# Altium schematic sheet 08_ATK_DLRK3506J_4G.SchDoc
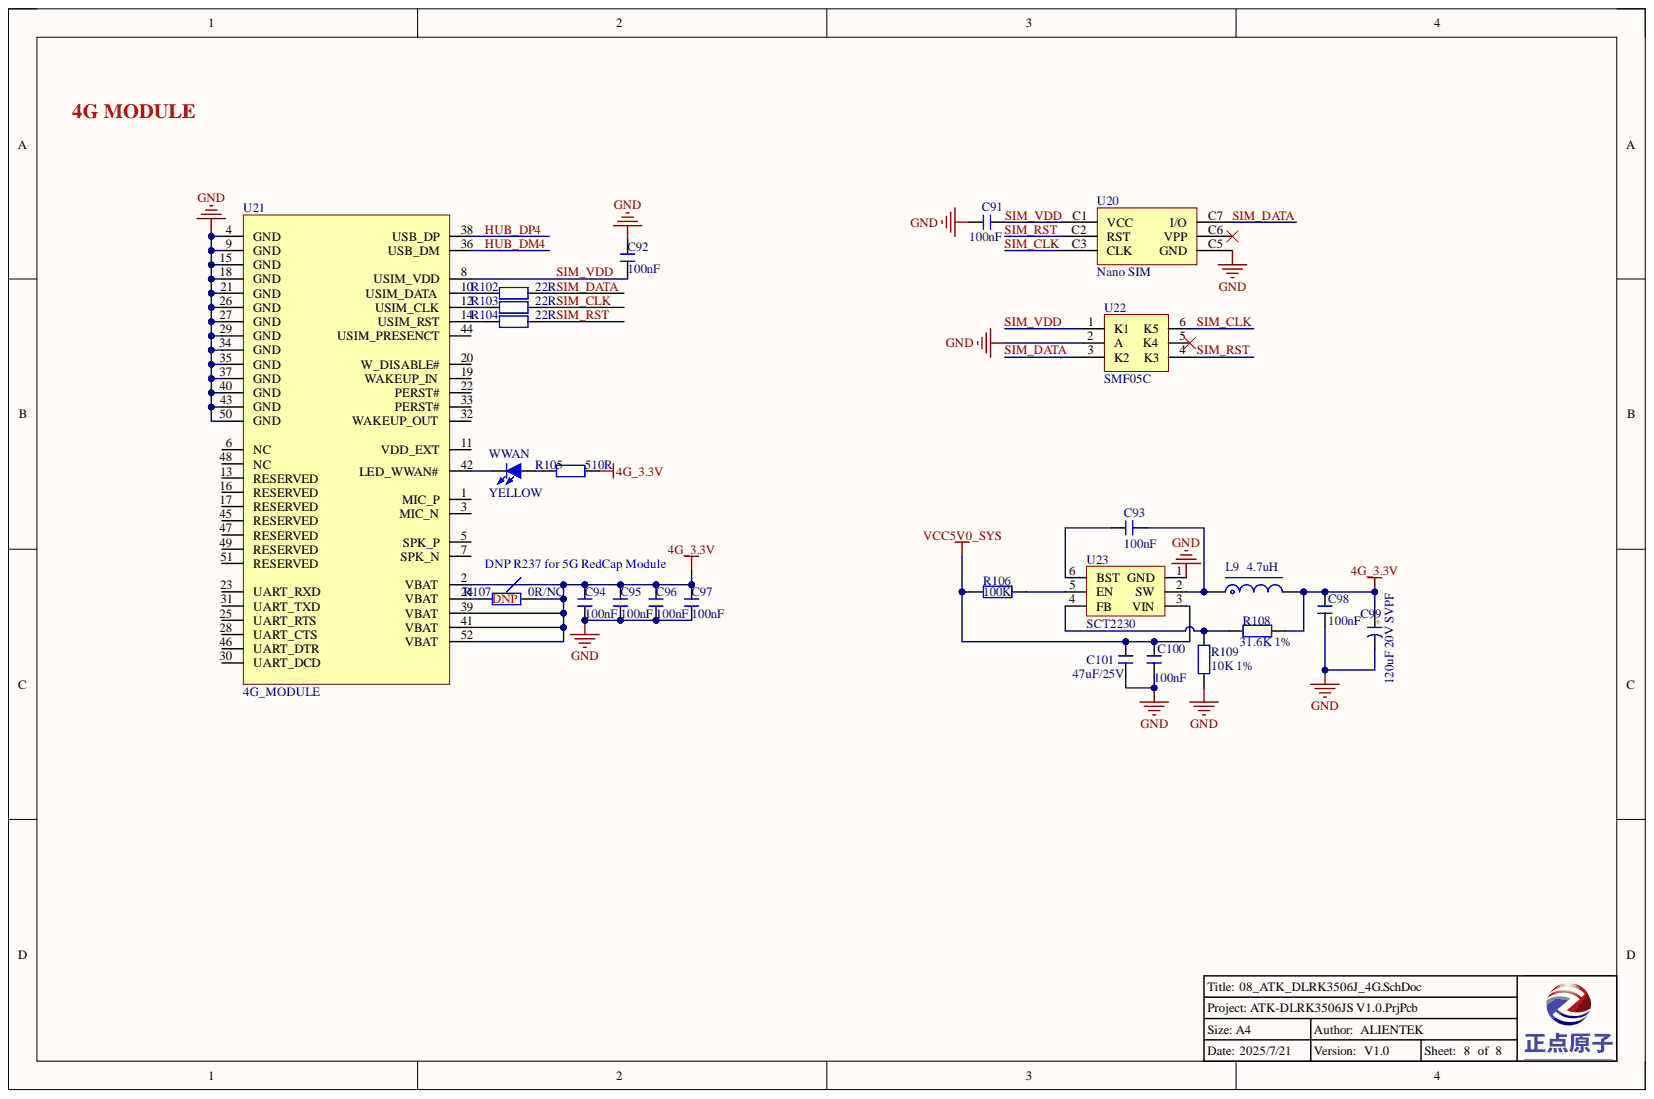
[diagram p0: 08_ATK_DLRK3506J_4G.SchDoc]

## Parts
C91  100nF  [C 0402]
C92  100nF  [C 0402]
C93  100nF  [C 0402]
C94  100nF  [C 0402]
C95  100nF  [C 0402]
C96  100nF  [C 0402]
C97  100nF  [C 0402]
C98  100nF  [C 0402]
C99  120uF 20V SVPF  [CM D(6.3*7.7)]
C100  100nF  [C 0402]
C101  47uF/25V  [C 1206]
L9  4.7uH  [L-SMD-0420]
R102  22R  [R 0402]
R103  22R  [R 0402]
R104  22R  [R 0402]
R105  510R  [R 0402]
R106  100K  [R 0402]
R107  0R/NC  [R 0402]
R108  31.6K 1%  [R 0402]
R109  10K 1%  [R 0402]
U20  Nano SIM  [Nano SIM 7P]
U21  4G_MODULE  [Mini PCIE 52P]
U22  SMF05C  [SOT363-6L]
U23  SCT2230  [SOT23-6N]
WWAN  YELLOW  [LED 0805G]

## Nets
4G_3.3V: C94.1 C95.1 C96.1 C97.1 C98.1 C99.1 L9.2 R105.1 R107.1 R108.2 U21.2(VBAT) U21.39(VBAT) U21.41(VBAT) U21.52(VBAT)
GND: C91.1 C92.1 C94.2 C95.2 C96.2 C97.2 C98.2 C99.2 C100.2 C101.1 R109.1 U20.C5(GND) U21.4(GND) U21.9(GND) U21.15(GND) U21.18(GND) U21.21(GND) U21.26(GND) U21.27(GND) U21.29(GND) U21.34(GND) U21.35(GND) U21.37(GND) U21.40(GND) U21.43(GND) U21.50(GND) U22.2(A) U23.1(GND)
VCC5V0_SYS: C100.1 C101.2 R106.1 U23.3(VIN)
HUB_DM4: U21.36(USB_DM)
HUB_DP4: U21.38(USB_DP)
SIM_CLK: R103.1 U20.C3(CLK) U22.6(K5)
SIM_DATA: R102.1 U20.C7(I/O) U22.3(K2)
SIM_RST: R104.1 U20.C2(RST) U22.4(K3)
SIM_VDD: C91.2 C92.2 U20.C1(VCC) U21.8(USIM_VDD) U22.1(K1)
NetC93_1: C93.1 U23.6(BST)
NetC93_2: C93.2 L9.1 U23.2(SW)
NetR102_2: R102.2 U21.10(USIM_DATA)
NetR103_2: R103.2 U21.12(USIM_CLK)
NetR104_2: R104.2 U21.14(USIM_RST)
NetR105_2: R105.2 WWAN.1
NetR106_2: R106.2 U23.5(EN)
NetR107_2: R107.2 U21.24(VBAT)
NetR108_1: R108.1 R109.2 U23.4(FB)
NetU21_42: U21.42(LED_WWAN#) WWAN.2

Not connected: U20 C6(VPP); U21 1(MIC_P) 3(MIC_N) 5(SPK_P) 6(NC) 7(SPK_N) 11(VDD_EXT) 13(RESERVED) 16(RESERVED) 17(RESERVED) 19(WAKEUP_IN) 20(W_DISABLE#) 22(PERST#) 23(UART_RXD) 25(UART_RTS) 28(UART_CTS) 30(UART_DCD) 31(UART_TXD) 32(WAKEUP_OUT) 33(PERST#) 44(USIM_PRESENCT) 45(RESERVED) 46(UART_DTR) 47(RESERVED) 48(NC) 49(RESERVED) 51(RESERVED); U22 5(K4)

## Library data of the parts
C91 C92 C94-C97 = C: 无极性电容; BOM名称: 无极性贴片电容; 版权归属: 正点原子; Component Kind: Standard; Library Name: 正点原子封装库V1.0.IntLib; 学习资源: www.openedv.com
C93 C98 C100 C101 = C: 无极性贴片电容; 版权归属: 源创客; BOM名称: 无极性贴片电容; Component Kind: Standard; Library Name: 电阻-电容-电感.SchLib; 学习资源: www.ooocke.com
C99 = C-CM: 贴片电解电容; 版权归属: 源创客; BOM名称: 贴片电解电容; Component Kind: Standard; Library Name: 电阻-电容-电感.SchLib; 学习资源: www.ooocke.com
L9 = L-CDRH: 功率屏蔽电感; 版权归属: 源创客; BOM名称: 功率屏蔽电感; Component Kind: Standard; Library Name: 电阻-电容-电感.SchLib; 学习资源: www.ooocke.com
R102-R109 = R: 贴片电阻; BOM名称: 电阻; 版权归属: 正点原子; Component Kind: Standard; Library Name: 电阻-电容-电感.SchLib; 学习资源: www.openedv.com
U21 = 4G-EC20: Component Kind: Standard; Library Name: 模块.SchLib
U22 = SMF05C: 5线TVS管; Parameter: 芯片; 版权归属: 正点原子; Component Kind: Standard; Library Name: 正点原子封装库V1.0.IntLib; 学习资源: www.openedv.com
U23 = DC JW5060T_6: DC降压芯片; Component Kind: Standard; Library Name: LDO和DCDC.SchLib
WWAN = LED-SMD: 贴片LED; 版权归属: 正点原子; BOM名称: 贴片LED; Component Kind: Standard; 学习资源: www.openedv.com; Library Name: Lycreturn.IntLib
U20 = Nano SIM: Component Kind: Standard; Library Name: ZZK_COMPONENT.IntLib
